# Altium schematic sheet P770010E05-Xx-SH-6_Sensors.SchDoc(P770010E05-Xx-SH-6_Sensors)
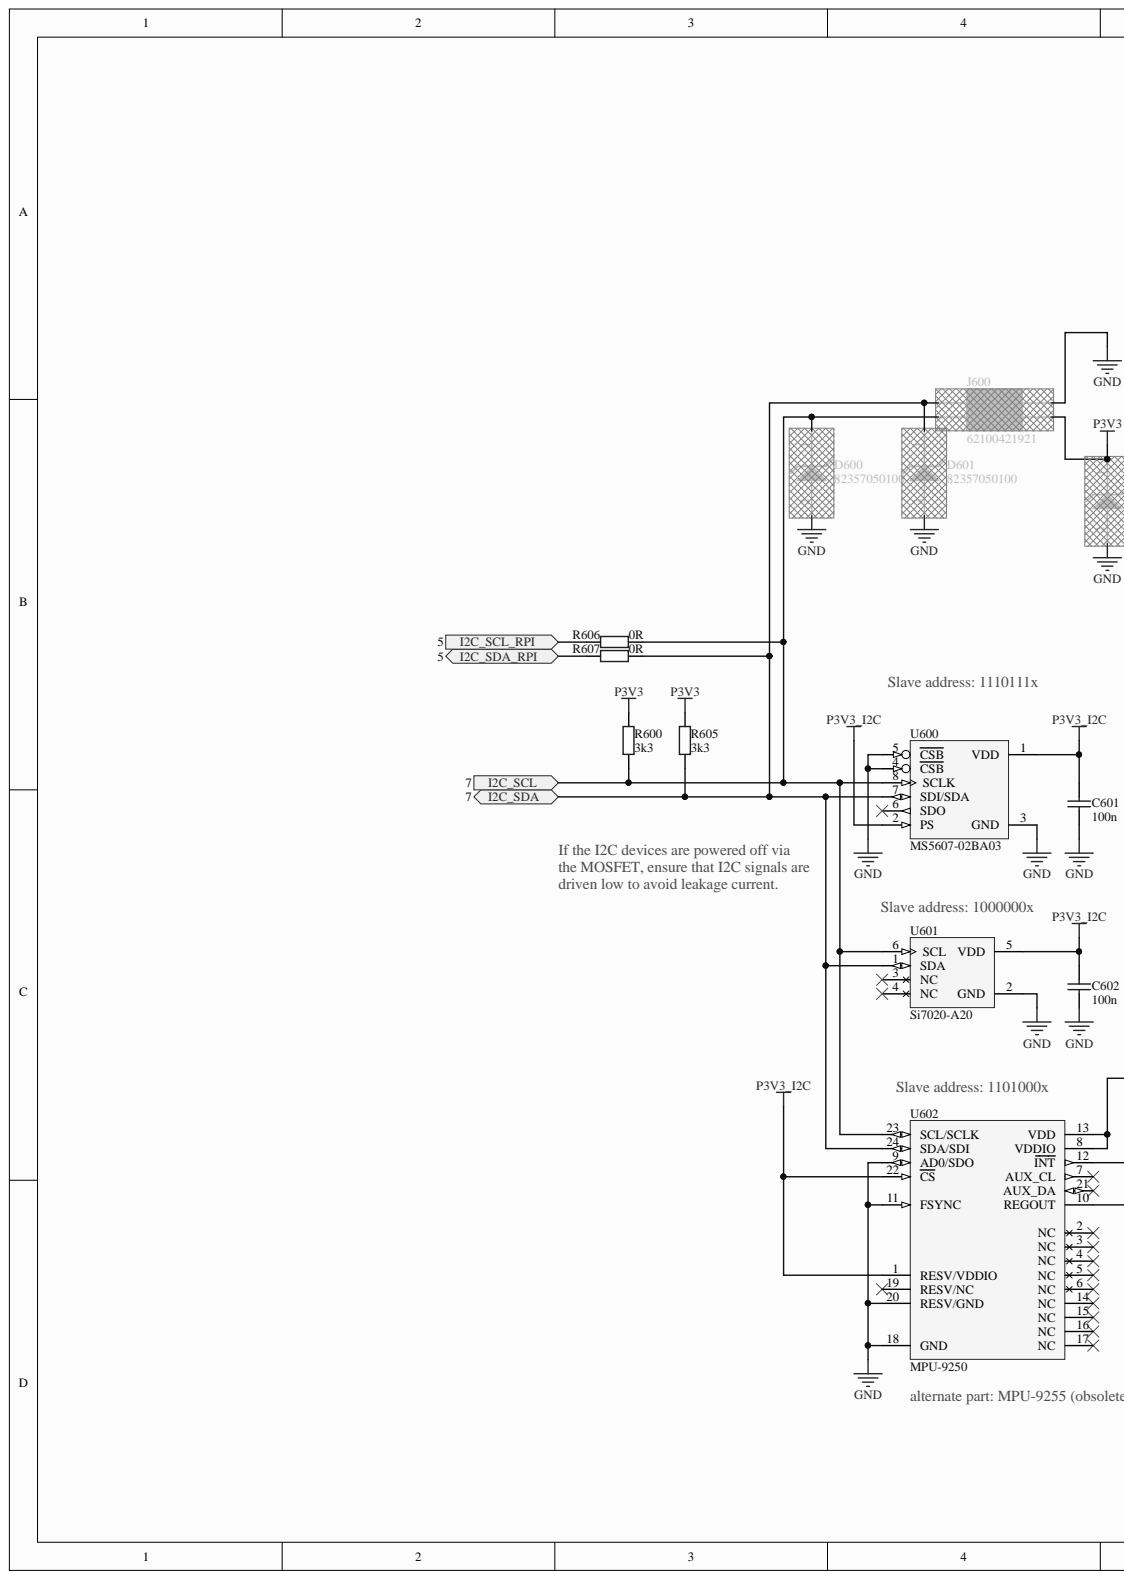
[diagram p0: P770010E05-Xx-SH-6_Sensors.SchDoc, left]
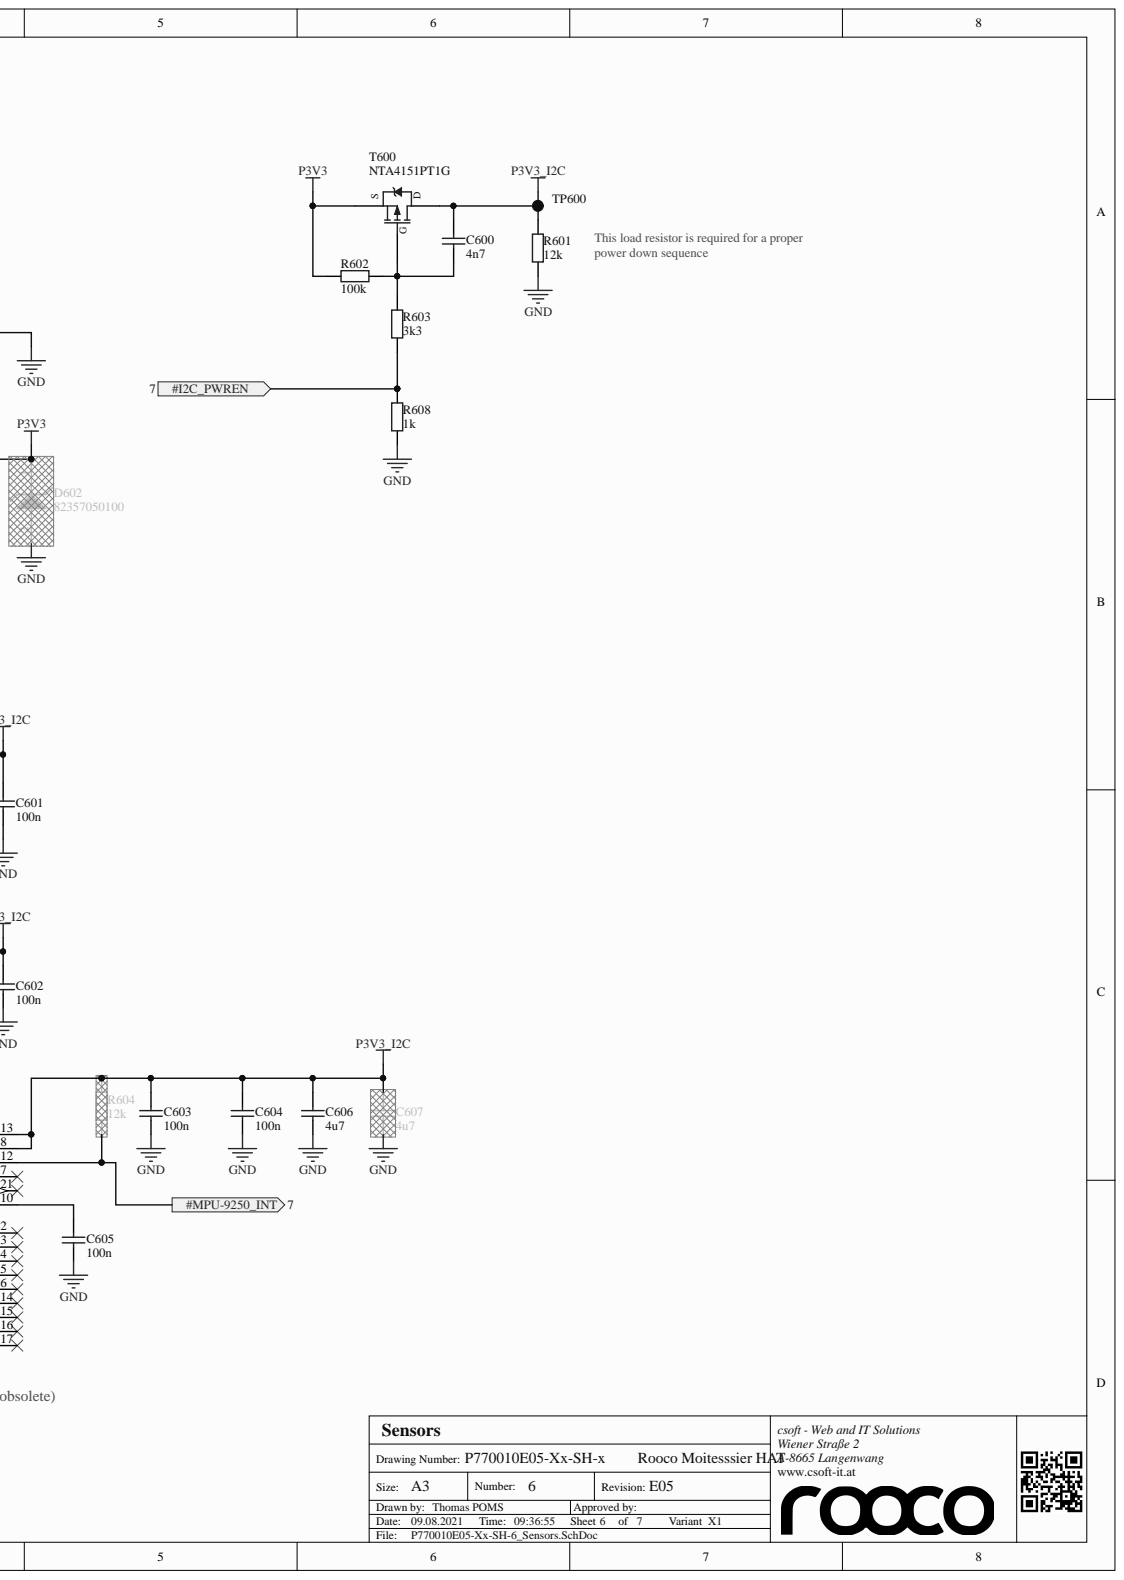
[diagram p1: P770010E05-Xx-SH-6_Sensors.SchDoc, right]

## Parts
C600  4n7  [C0402]
C601  100n  [C0402]
C602  100n  [C0402]
C603  100n  [C0402]
C604  100n  [C0402]
C605  100n  [C0402]
C606  4u7  [C0402]
C607  4u7  [C0402]
D600  82357050100  [82357x]
D601  82357050100  [82357x]
D602  82357050100  [82357x]
J600  62100421921  [62100421921]
R600  3k3  [R0402]
R601  12k  [R0402]
R602  100k  [R0402]
R603  3k3  [R0402]
R604  12k  [R0402]
R605  3k3  [R0402]
R606  0R  [R0402]
R607  0R  [R0402]
R608  1k  [R0402]
T600  NTA4151PT1G  [NTA4151PT1G_SOT-416]
TP600  testpoint  [TP_1.27x1.27]  (value not drawn)  (no BOM)
U600  MS5607-02BA03  [MS5607-02BA03]
U601  Si7020-A20  [Si7020-A20_DFN-6]
U602  MPU-9250  [MPU-9250_QFN-24]

## Ports
#I2C_PWREN, #MPU-9250_INT, I2C_SCL, I2C_SCL_RPI, I2C_SDA, I2C_SDA_RPI

## Nets
GND: C601.1 C602.1 C603.1 C604.1 C605.1 C606.1 C607.1 D600.2 D601.2 D602.2 J600.2 R601.1 R608.2 U600.3(GND) U600.4(CSB) U600.5(CSB) U601.2(GND) U602.9(AD0/SDO) U602.11(FSYNC) U602.18(GND) U602.20(RESV/GND)
P3V3: D602.1 J600.4 R600.2 R602.2 R605.2 T600.S
P3V3_I2C: C600.1 C601.2 C602.2 C603.2 C604.2 C606.2 C607.2 R601.2 R604.2 T600.D TP600.1 U600.1(VDD) U600.2(PS) U601.5(VDD) U602.1(RESV/VDDIO) U602.8(VDDIO) U602.13(VDD) U602.22(CS)
#I2C_PWREN: R603.1 R608.1 ; port #I2C_PWREN
#MPU-9250_INT: R604.1 U602.12(INT) ; port #MPU-9250_INT
I2C_SCL: D600.1 J600.3 R600.1 R606.1 U600.8(SCLK) U601.6(SCL) U602.23(SCL/SCLK) ; port I2C_SCL
I2C_SCL_RPI: R606.2 ; port I2C_SCL_RPI
I2C_SDA: D601.1 J600.1 R605.1 R607.2 U600.7(SDI/SDA) U601.1(SDA) U602.24(SDA/SDI) ; port I2C_SDA
I2C_SDA_RPI: R607.1 ; port I2C_SDA_RPI
NetC600_2: C600.2 R602.1 R603.2 T600.G
NetC605_2: C605.2 U602.10(REGOUT)

Not connected: U600 6(SDO); U601 3(NC) 4(NC); U602 2(NC) 3(NC) 4(NC) 5(NC) 6(NC) 7(AUX_CL) 14(NC) 15(NC) 16(NC) 17(NC) 19(RESV/NC) 21(AUX_DA)

## Library data of the parts
C600 = C0402C472K5RACTU: ceramic chip capacitor, 4.7nF, 50V, X7R, 0402; Manufacturer: Kemet; ID: 79; Obsolete: False; Published by: FT-TEC; RevisionHistory: [1.0] Creation; ToleranceValue: +/-10%; Component Kind: Standard; ComponentLink1Description: Datasheet; ComponentLink1URL: http://www.kemet.com/Lists/ProductCatalog/Attachments/53/KEM_C1002_X7R_SMD.pdf; ComponentType: Standard; Database Table Name: Capacitor; Dielectric: X7R; Library Name: CompanyDatabase.DbLib; Package: 0402; Part Number: C0402C472K5RACTU; Pin Count: 2; Published: 15.06.2016; Revision: 1.0; TemperatureMax: 125°C; TemperatureMin: -55°C; Value: 4n7; VoltageTypical: 50V; Checked by: Thomas POMS; Drawn by: Thomas POMS
C601-C605 = C1005X7R1H104K050BB: ceramic chip capacitor, 100n, 50V, X7R, 0402; Manufacturer: TDK; ID: 1; Obsolete: False; Published by: FT-TEC; RevisionHistory: [1.0]; ToleranceValue: +/-10%; Component Kind: Standard; ComponentLink1Description: Datasheet; ComponentLink1URL: http://www.tdk.com/pdf/TDKMLCCCapRange.pdf; ComponentType: Standard; Database Table Name: Capacitor; Dielectric: X7R; Library Name: CompanyDatabase.DbLib; Package: 0402; Part Number: C1005X7R1H104K050BB; Pin Count: 2; Published: 16.02.2012; Revision: 1.0; TemperatureMax: 125°C; TemperatureMin: -55°C; Value: 100n; VoltageTypical: 50V; Checked by: Thomas POMS; Drawn by: Thomas POMS
C606 C607 = C1005X5R0J475K: ceramic chip capacitor, 4.7uF, 6.3V, X5R, 0402; Manufacturer: TDK; ID: 10; Obsolete: False; Published by: FT-TEC; RevisionHistory: [1.0]; ToleranceValue: +/-15%; Component Kind: Standard; ComponentLink1Description: Datasheet; ComponentLink1URL: http://www.tdk.com/pdf/TDKMLCCCapRange.pdf; ComponentType: Standard; Database Table Name: Capacitor; Dielectric: X5R; Library Name: CompanyDatabase.DbLib; Package: 0402; Part Number: C1005X5R0J475K; Pin Count: 2; Published: 24.02.2012; Revision: 1.0; TemperatureMax: 85°C; TemperatureMin: -55°C; Value: 4u7; VoltageTypical: 6.3V; Checked by: Thomas POMS; Drawn by: Thomas POMS
D600-D602 = 82357050100: ESD suppressor, 5VDC, 60Vclamp, 10pF; Manufacturer: Würth; Database Table Name: Diode; ID: 27; Obsolete: False; Published by: FT-TEC; RevisionHistory: [1.0] Creation; Component Kind: Standard; ComponentLink1Description: Datasheet; ComponentLink1URL: http://katalog.we-online.de/pbs/datasheet/82357050100.pdf; ComponentType: Standard; Library Name: CompanyDatabase.DbLib; Package: 0402; Part Number: 82357050100; Pin Count: 2; Published: 30.07.2015; Revision: 1.0; TemperatureMax: 85°C; TemperatureMin: -40°C; Value: 82357050100; Checked by: Thomas POMS; Drawn by: Thomas POMS
J600 = 62100421921: header, 2mm, 2x2pin, vertical; Manufacturer: Würth; CurrentRating: 2.0A; ID: 35; Obsolete: False; Published by: poms-engineering; RevisionHistory: [1.0] Creation; Component Kind: Standard; ComponentLink1Description: Datasheet; ComponentLink1URL: http://katalog.we-online.de/em/datasheet/6210xx21921.pdf; ComponentType: Standard; Database Table Name: Header; Library Name: CompanyDatabase.DbLib; Part Number: 62100421921; PinClearance: 2mm; Pin Count: 4; Published: 04.09.2019; Revision: 1.0; TemperatureMax: 125°C; TemperatureMin: -40°C; Value: 62100421921; Checked by: Thomas POMS; Drawn by: Thomas POMS
R600 R603 R605 = CRCW04023K30FKED: thick film resistor, 3.3k, 1%, 100ppm,  0402; Manufacturer: Vishay; ID: 20; Obsolete: False; PowerRating: 63mW; Published by: FT-TEC; RevisionHistory: [1.0] Creation; VoltageRating: 50V; Component Kind: Standard; ComponentLink1Description: Datasheet; ComponentLink1URL: http://www.vishay.com/docs/20035/dcrcwe3.pdf; ComponentType: Standard; Database Table Name: Resistor; Library Name: CompanyDatabase.DbLib; Package: 0402; Part Number: CRCW04023K30FKED; Pin Count: 2; Published: 27.02.2012; Revision: 1.0; TemperatureCoefficient: 100ppm; TemperatureMax: 155°C; TemperatureMin: -55°C; ToleranceValue: 1%; Value: 3k3; Checked by: Thomas POMS; Drawn by: Thomas Poms
R601 R604 = CRCW040212K0FKED: thick film resistor, 12k, 1%, 100ppm, 0402, 63mW; Manufacturer: Vishay; ID: 103; Obsolete: False; Published by: FT-TEC; RevisionHistory: [1.0] Creation; VoltageRating: 50V; Component Kind: Standard; ComponentLink1Description: Datasheet; ComponentLink1URL: http://www.vishay.com/docs/20035/dcrcwe3.pdf; ComponentType: Standard; Database Table Name: Resistor; Library Name: CompanyDatabase.DbLib; Package: 0402; Part Number: CRCW040212K0FKED; Pin Count: 2; PowerRating: 63mW; Published: 04.04.2017; Revision: 1.0; TemperatureCoefficient: 100ppm; TemperatureMax: 155°C; TemperatureMin: -55°C; ToleranceValue: 1%; Value: 12k; Checked by: Thomas POMS; Drawn by: Thomas POMS
R602 = CRCW0402100KFKED: thick film resistor, 100k, 1%, 100ppm, 0402; Manufacturer: Vishay; ID: 6; Obsolete: False; PowerRating: 63mW; Published by: FT-TEC; RevisionHistory: [1.0] Creation; VoltageRating: 50V; Component Kind: Standard; ComponentLink1Description: Datasheet; ComponentLink1URL: http://www.vishay.com/docs/20035/dcrcwe3.pdf; ComponentType: Standard; Database Table Name: Resistor; Library Name: CompanyDatabase.DbLib; Package: 0402; Part Number: CRCW0402100KFKED; Pin Count: 2; Published: 23.02.2012; Revision: 1.0; TemperatureCoefficient: 100ppm; TemperatureMax: 155°C; TemperatureMin: -55°C; ToleranceValue: 1%; Value: 100k; Checked by: Thomas POMS; Drawn by: Thomas Poms
R606 R607 = CRCW04020000Z0ED: thick film resistor, 0R, 1%, 100ppm, 0402; Manufacturer: Vishay; ID: 2; Obsolete: False; PowerRating: 63mW; Published by: FT-TEC; RevisionHistory: [1.0] Creation; VoltageRating: 50V; Component Kind: Standard; ComponentType: Standard; Database Table Name: Resistor; Library Name: CompanyDatabase.DbLib; Package: 0402; Part Number: CRCW04020000Z0ED; Pin Count: 2; Published: 16.02.2012; Revision: 1.0; TemperatureCoefficient: 100ppm; TemperatureMax: 155°C; TemperatureMin: -55°C; ToleranceValue: 1%; Value: 0R; Checked by: Thomas POMS; Drawn by: Thomas Poms
R608 = CRCW04021K00FKED: thick film resistor, 1k, 1%, 100ppm, 0402; Manufacturer: Vishay; ID: 4; Obsolete: False; PowerRating: 63mW; Published by: FT-TEC; RevisionHistory: [1.0] Creation; VoltageRating: 50V; Component Kind: Standard; ComponentLink1Description: Datasheet; ComponentLink1URL: http://www.vishay.com/docs/20035/dcrcwe3.pdf; ComponentType: Standard; Database Table Name: Resistor; Library Name: CompanyDatabase.DbLib; Package: 0402; Part Number: CRCW04021K00FKED; Pin Count: 2; Published: 16.02.2012; Revision: 1.0; TemperatureCoefficient: 100ppm; TemperatureMax: 155°C; TemperatureMin: -55°C; ToleranceValue: 1%; Value: 1k; Checked by: Thomas POMS; Drawn by: Thomas Poms
T600 = NTA4151PT1G: PMOS, -20V, -760mA; Manufacturer: ON Semiconductor; Database Table Name: MOSFET; ID: 14; Obsolete: False; Published by: FT-TEC; RDSon: 0.49R; RevisionHistory: [1.0] Creation; RJA: 415°C/W; VGS: +/-6V; VGS(th)Max: -1.2V; VGS(th)Min: -0.45V; Component Kind: Standard; ComponentLink1Description: Datasheet; ComponentLink1URL: http://www.onsemi.com/pub_link/Collateral/NTA4151P-D.PDF; ComponentType: Standard; DrainCurrent: -760mA; Library Name: CompanyDatabase.DbLib; Package: SOT-416; Part Number: NTA4151PT1G; Pin Count: 3; Published: 23.12.2015; Revision: 1.0; TemperatureMax: 150°C; TemperatureMin: -55°C; Type: PMOS; Value: NTA4151PT1G; VDSmax: -20V; Checked by: Thomas POMS; Drawn by: Thomas POMS
TP600 = TP_1.27x1.27: Testpoint 1.27mm x 1.27mm; Manufacturer: none; ID: 1; Obsolete: False; Published by: FT-TEC; RevisionHistory: [1.0] Creation; ComponentType: Standard; Database Table Name: Testpoint; Library Name: CompanyDatabase.DbLib; Part Number: TP_1.27x1.27; Revision: 1.0; Value: TP_1.27x1.27; Checked by: Thomas POMS; Drawn by: Thomas POMS
U600 = MS5607-02BA03: barometric pressure sensor, 10mBar to 1200mBar; Manufacturer: Measurement Specialties; ID: 5; Obsolete: False; Published by: FT-TEC; RevisionHistory: [1.0] Creation; VoltageMax: 3.6V; VoltageMin: 1.8V; VoltageTypical: 3.3V; Component Kind: Standard; ComponentLink1Description: Datasheet; ComponentLink1URL: http://www.meas-spec.com/product/t_product.aspx?id=8499&terms=MS5607-02BA03*#; ComponentType: Standard; Database Table Name: Sensor; Library Name: CompanyDatabase.DbLib; Package: QFN-8; Part Number: MS5607-02BA03; Pin Count: 8; Published: 30.07.2015; Revision: 1.0; TemperatureMax: 85°C; TemperatureMin: -40°C; Value: MS5607-02BA03; Checked by: Thomas POMS; Drawn by: Thomas POMS
U601 = Si7020-A20: humidity and temperature sensor; Manufacturer: Silicon Labs; ID: 6; Obsolete: False; Published by: FT-TEC; RevisionHistory: [1.0] Creation; VoltageMax: 3.6V; VoltageMin: 1.9V; VoltageTypical: 3.3V; Component Kind: Standard; ComponentLink1Description: Datasheet; ComponentLink1URL: https://www.silabs.com/Support%20Documents%2fTechnicalDocs%2fSi7020-A20.pdf; ComponentType: Standard; Database Table Name: Sensor; Library Name: CompanyDatabase.DbLib; Package: DFN-6; Part Number: Si7020-A20; Pin Count: 6; Published: 03.08.2015; Revision: 1.0; TemperatureMax: 125°C; TemperatureMin: -40°C; Value: Si7020-A20; Checked by: Thomas POMS; Drawn by: Thomas POMS
U602 = MPU-9250: 9-axis (gyro + accelerometer + compass) MEMS motion tracking device; Manufacturer: InvenSense; Database Table Name: Sensor; ID: 7; Obsolete: False; Published by: FT-TEC; RevisionHistory: [1.0] Creation; VoltageMax: 2.5V; VoltageMin: 2.4V; VoltageTypical: 3.6V; Component Kind: Standard; ComponentLink1Description: Datasheet; ComponentLink1URL: http://www.invensense.com/wp-content/uploads/2015/02/PS-MPU-9250A-01-v1.1.pdf; ComponentType: Standard; Library Name: CompanyDatabase.DbLib; Package: QFN-24; Part Number: MPU-9250; Pin Count: 24; Published: 19.10.2017; Revision: 1.0; TemperatureMax: 105°C; TemperatureMin: -40°C; Value: MPU-9250; Checked by: Thomas POMS; Drawn by: Thomas POMS
